# Altium schematic sheet SensorBreakout.SchDoc(SensorBreakout)
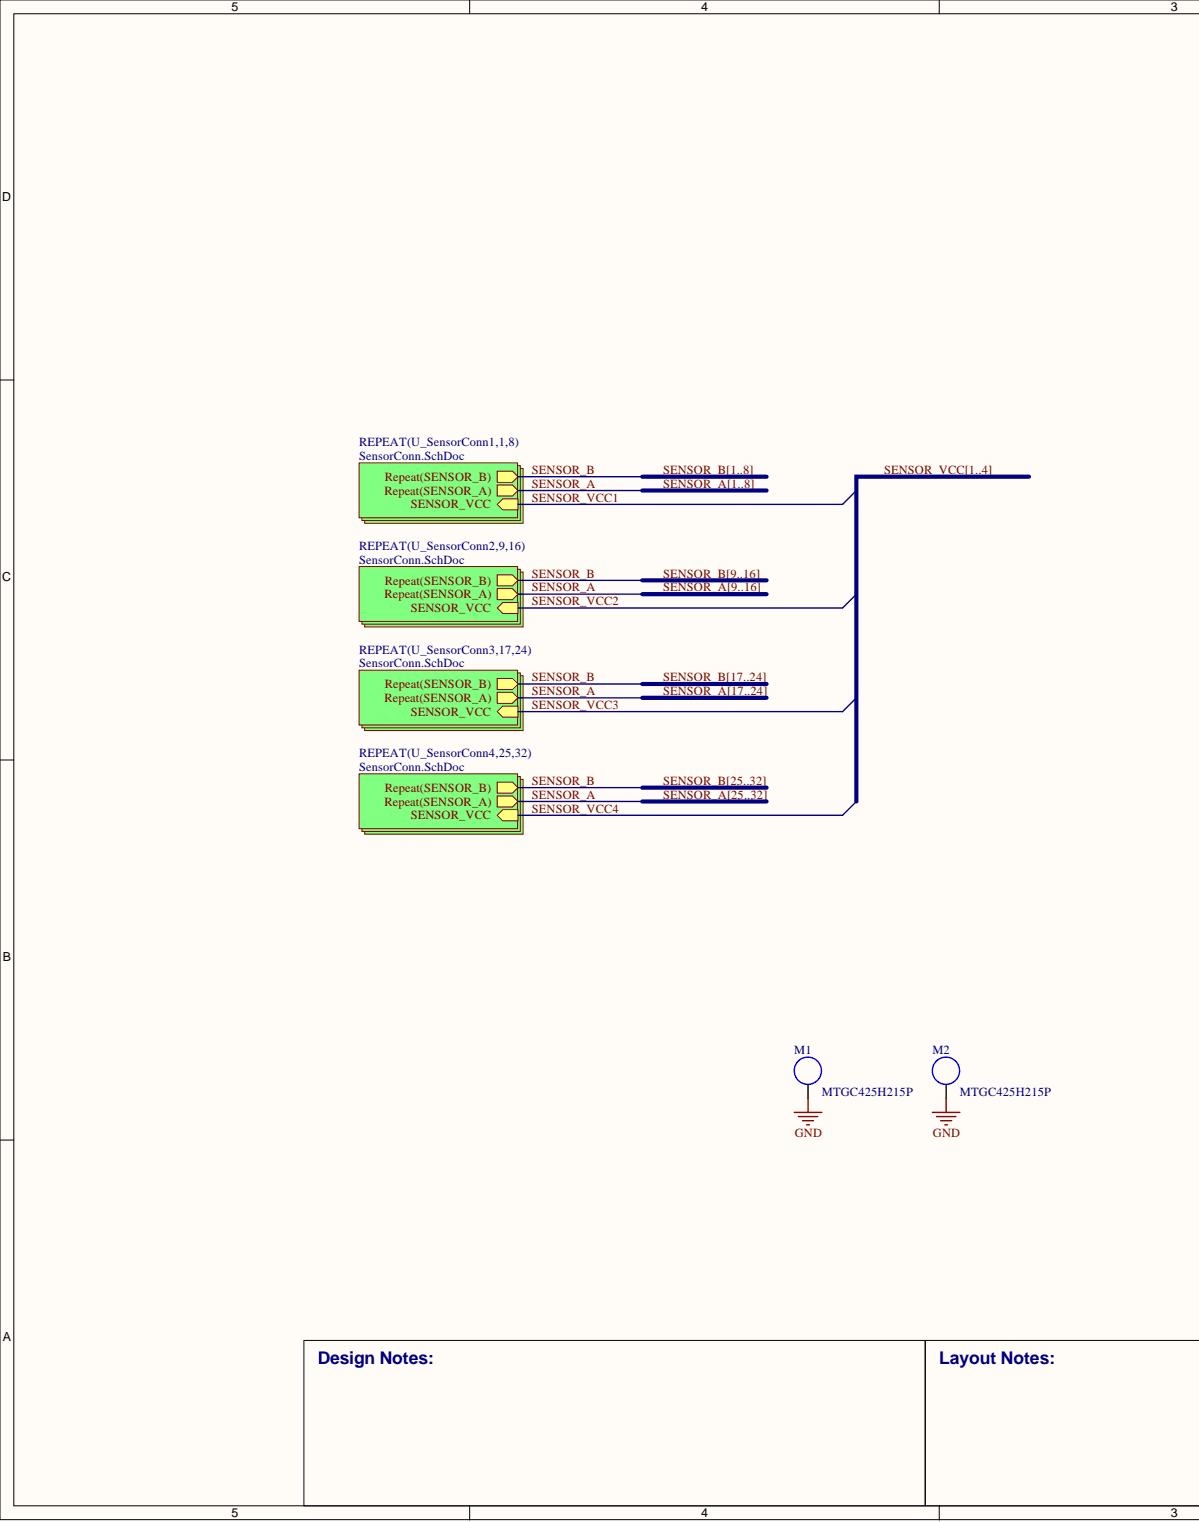
[diagram p0: SensorBreakout.SchDoc, left]
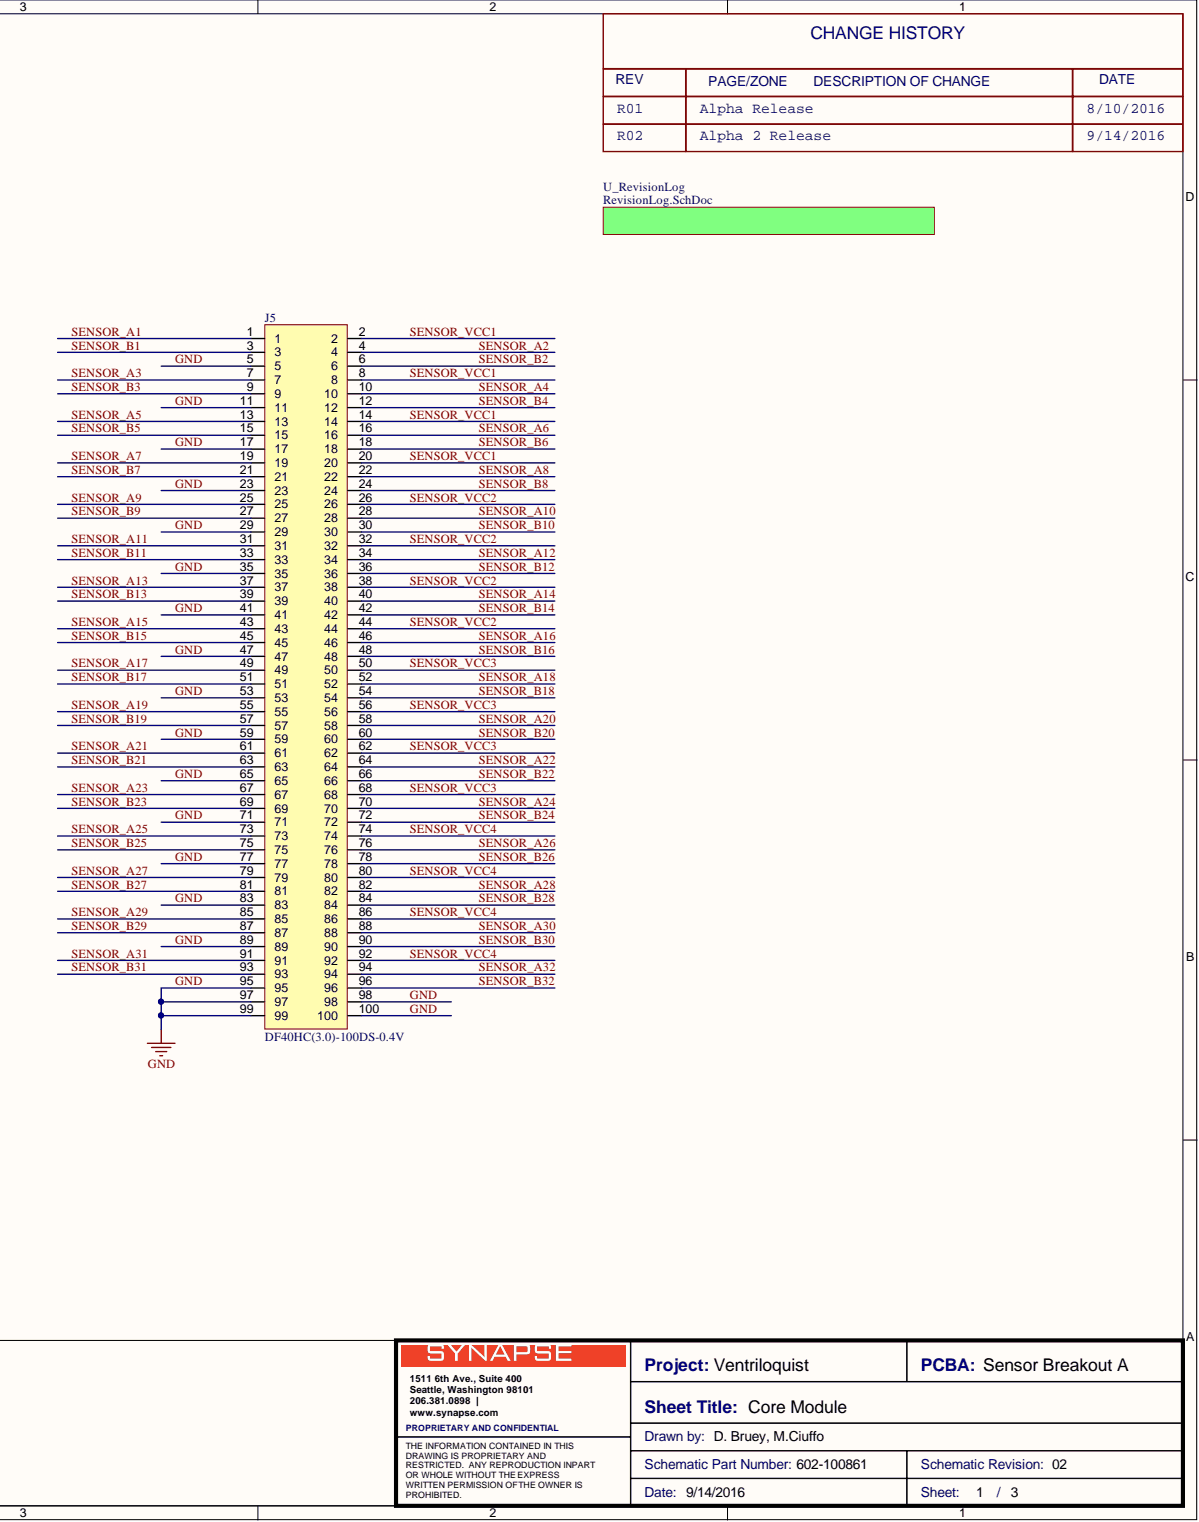
[diagram p1: SensorBreakout.SchDoc, right]

## Parts
J5  DF40HC(3.0)-100DS-0.4V  [con100_hirose-df40c-100ds_129h]
M1  MTGC425H215P  [MTGC425H215P]  (no BOM)
M2  MTGC425H215P  [MTGC425H215P]  (no BOM)

## Nets
GND: J5.5 J5.11 J5.17 J5.23 J5.29 J5.35 J5.41 J5.47 J5.53 J5.59 J5.65 J5.71 J5.77 J5.83 J5.89 J5.95 J5.97 J5.98 J5.99 J5.100 M1.1 M2.1
SENSOR_A1: J5.1  [also labelled SENSOR_A]
SENSOR_A2: J5.4  [also labelled SENSOR_A]
SENSOR_A3: J5.7  [also labelled SENSOR_A]
SENSOR_A4: J5.10  [also labelled SENSOR_A]
SENSOR_A5: J5.13  [also labelled SENSOR_A]
SENSOR_A6: J5.16  [also labelled SENSOR_A]
SENSOR_A7: J5.19  [also labelled SENSOR_A]
SENSOR_A8: J5.22  [also labelled SENSOR_A]
SENSOR_A9: J5.25  [also labelled SENSOR_A]
SENSOR_A10: J5.28  [also labelled SENSOR_A]
SENSOR_A11: J5.31  [also labelled SENSOR_A]
SENSOR_A12: J5.34  [also labelled SENSOR_A]
SENSOR_A13: J5.37  [also labelled SENSOR_A]
SENSOR_A14: J5.40  [also labelled SENSOR_A]
SENSOR_A15: J5.43  [also labelled SENSOR_A]
SENSOR_A16: J5.46  [also labelled SENSOR_A]
SENSOR_A17: J5.49  [also labelled SENSOR_A]
SENSOR_A18: J5.52  [also labelled SENSOR_A]
SENSOR_A19: J5.55  [also labelled SENSOR_A]
SENSOR_A20: J5.58  [also labelled SENSOR_A]
SENSOR_A21: J5.61  [also labelled SENSOR_A]
SENSOR_A22: J5.64  [also labelled SENSOR_A]
SENSOR_A23: J5.67  [also labelled SENSOR_A]
SENSOR_A24: J5.70  [also labelled SENSOR_A]
SENSOR_A25: J5.73  [also labelled SENSOR_A]
SENSOR_A26: J5.76  [also labelled SENSOR_A]
SENSOR_A27: J5.79  [also labelled SENSOR_A]
SENSOR_A28: J5.82  [also labelled SENSOR_A]
SENSOR_A29: J5.85  [also labelled SENSOR_A]
SENSOR_A30: J5.88  [also labelled SENSOR_A]
SENSOR_A31: J5.91  [also labelled SENSOR_A]
SENSOR_A32: J5.94  [also labelled SENSOR_A]
SENSOR_B1: J5.3  [also labelled SENSOR_B]
SENSOR_B2: J5.6  [also labelled SENSOR_B]
SENSOR_B3: J5.9  [also labelled SENSOR_B]
SENSOR_B4: J5.12  [also labelled SENSOR_B]
SENSOR_B5: J5.15  [also labelled SENSOR_B]
SENSOR_B6: J5.18  [also labelled SENSOR_B]
SENSOR_B7: J5.21  [also labelled SENSOR_B]
SENSOR_B8: J5.24  [also labelled SENSOR_B]
SENSOR_B9: J5.27  [also labelled SENSOR_B]
SENSOR_B10: J5.30  [also labelled SENSOR_B]
SENSOR_B11: J5.33  [also labelled SENSOR_B]
SENSOR_B12: J5.36  [also labelled SENSOR_B]
SENSOR_B13: J5.39  [also labelled SENSOR_B]
SENSOR_B14: J5.42  [also labelled SENSOR_B]
SENSOR_B15: J5.45  [also labelled SENSOR_B]
SENSOR_B16: J5.48  [also labelled SENSOR_B]
SENSOR_B17: J5.51  [also labelled SENSOR_B]
SENSOR_B18: J5.54  [also labelled SENSOR_B]
SENSOR_B19: J5.57  [also labelled SENSOR_B]
SENSOR_B20: J5.60  [also labelled SENSOR_B]
SENSOR_B21: J5.63  [also labelled SENSOR_B]
SENSOR_B22: J5.66  [also labelled SENSOR_B]
SENSOR_B23: J5.69  [also labelled SENSOR_B]
SENSOR_B24: J5.72  [also labelled SENSOR_B]
SENSOR_B25: J5.75  [also labelled SENSOR_B]
SENSOR_B26: J5.78  [also labelled SENSOR_B]
SENSOR_B27: J5.81  [also labelled SENSOR_B]
SENSOR_B28: J5.84  [also labelled SENSOR_B]
SENSOR_B29: J5.87  [also labelled SENSOR_B]
SENSOR_B30: J5.90  [also labelled SENSOR_B]
SENSOR_B31: J5.93  [also labelled SENSOR_B]
SENSOR_B32: J5.96  [also labelled SENSOR_B]
SENSOR_VCC1: J5.2 J5.8 J5.14 J5.20  [also labelled SENSOR_VCC]
SENSOR_VCC2: J5.26 J5.32 J5.38 J5.44  [also labelled SENSOR_VCC]
SENSOR_VCC3: J5.50 J5.56 J5.62 J5.68  [also labelled SENSOR_VCC]
SENSOR_VCC4: J5.74 J5.80 J5.86 J5.92  [also labelled SENSOR_VCC]

## Library data of the parts
J5 = DF40HC(3.0)-100DS-0.4V(51): CONN RCPT 100POS 0.4MM SMD GOLD 3.0MM; part_type: connector; Database Table Name: viewAltiumConnectors; dist: Mouser; dist_pn: 798-DF40HC30100DS451; id: 1590; mfg: Hirose; positions: 100; voltage: 30V; Component Kind: Standard; datasheet: http://www.hirose.co.jp/cataloge_hp/ed_DF40_20140305.pdf; height: 3.0mm; mfg_pn: DF40HC(3.0)-100DS-0.4V(51); source_package: DF40HC(3.0)-100DS-0.4V(51); status: Unknown; TableName: viewAltiumConnectors; Library Name: SynapseLibrary.DbLib
M1 M2 = MTGC425H215P: MTG, 4.25mm PAD, 2.15mm Plated Hole; part_type: mounting; Database Table Name: viewAltiumMisc; dist_pn: None; id: 1240; height: 0.05MM; mfg_pn: MTGC425H215P; status: Unknown; TableName: viewAltiumMisc; Library Name: SynapseLibrary.DbLib
